# Altium schematic sheet FPGA.SchDoc
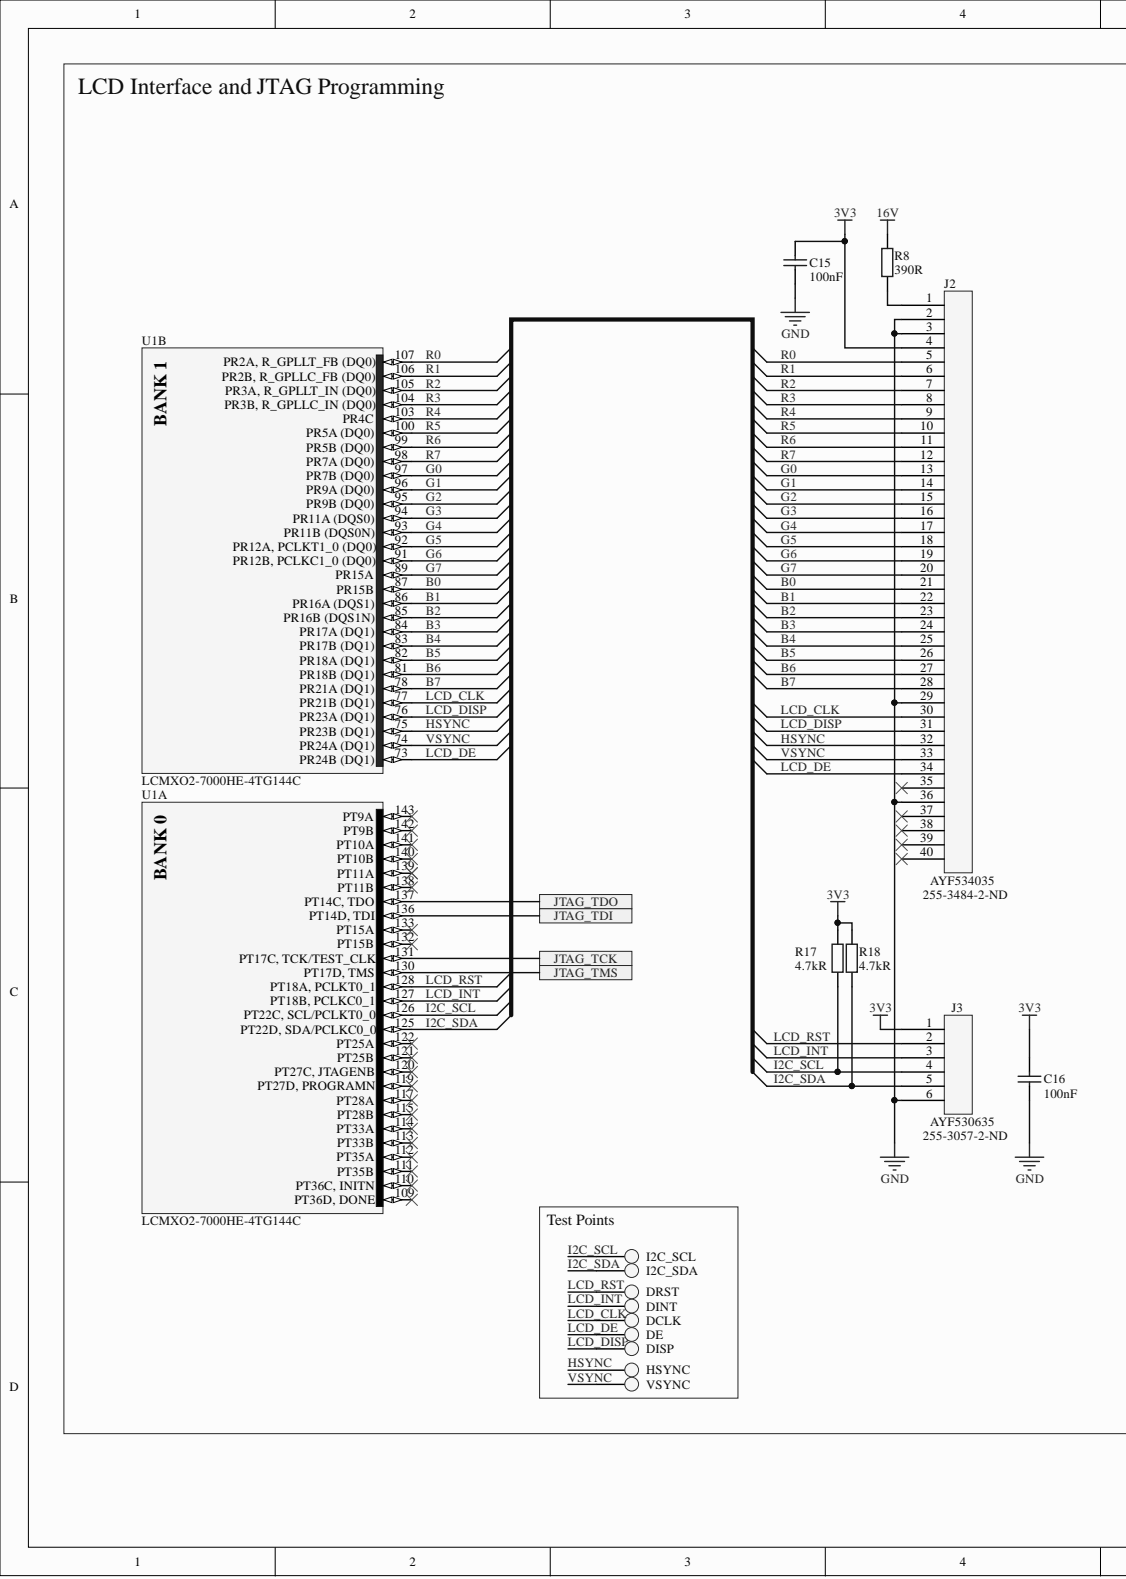
[diagram p0: FPGA.SchDoc, left]
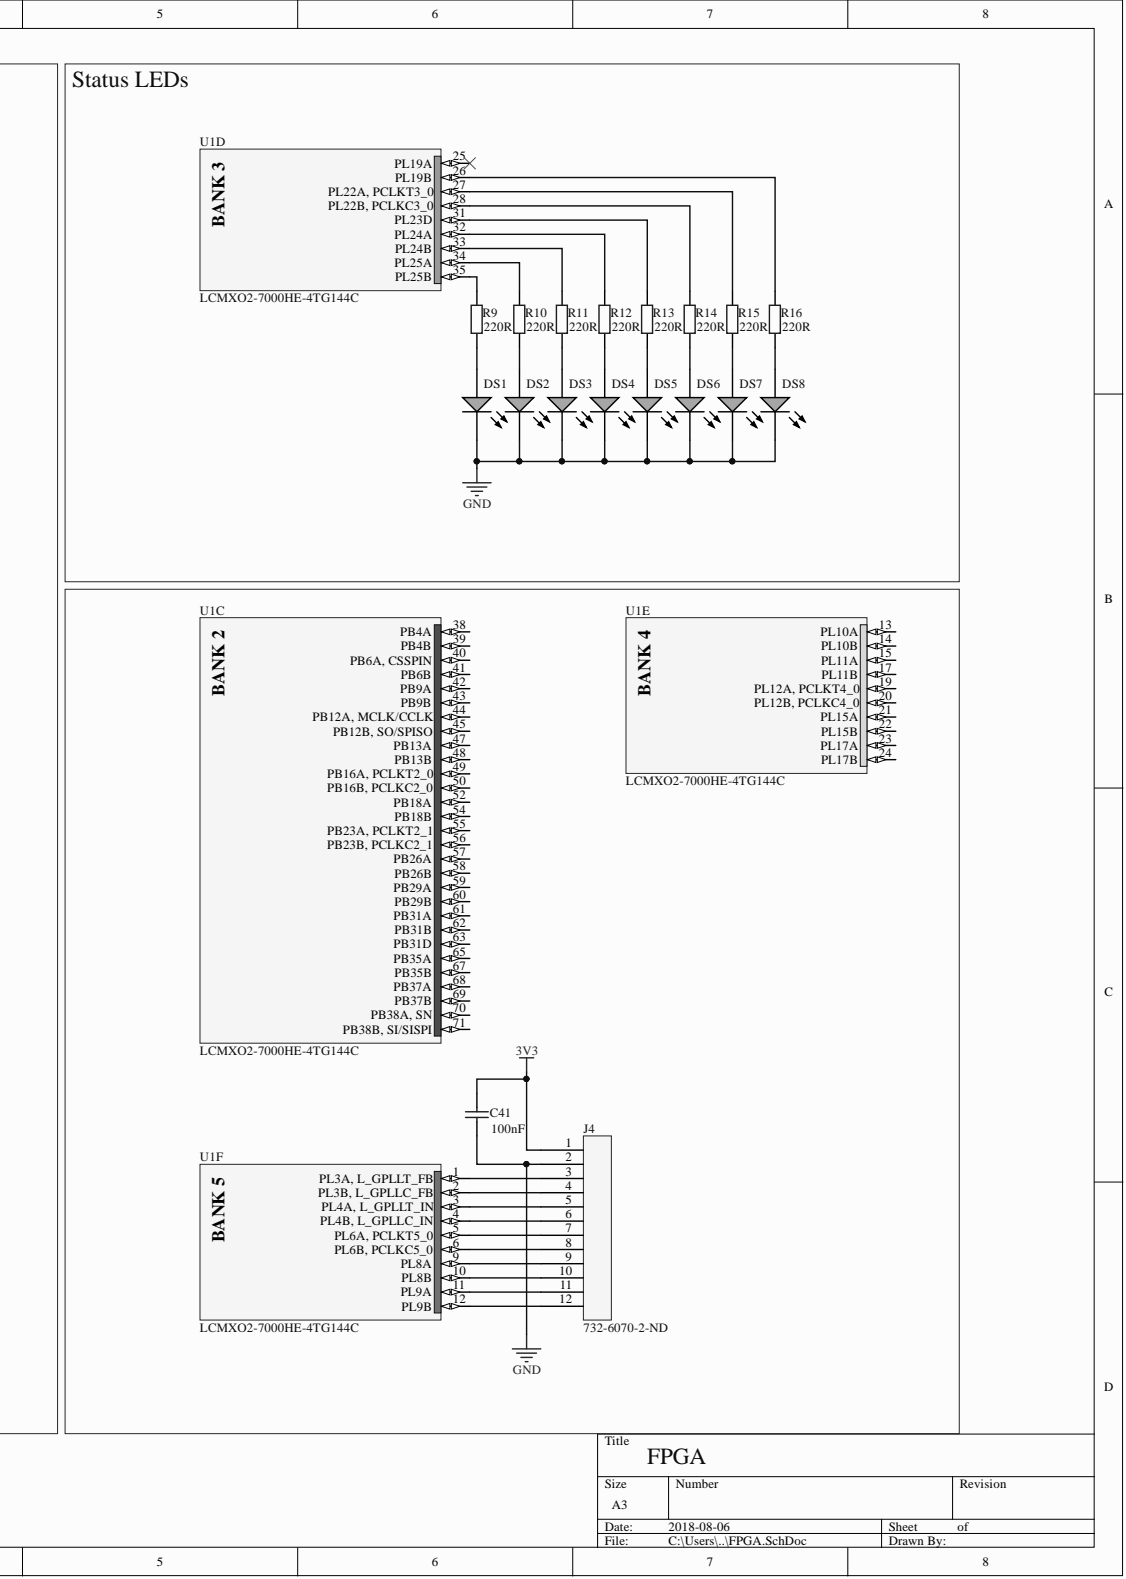
[diagram p1: FPGA.SchDoc, right]

## Parts
C15  Capacitance: 100nF  [CAP_0402_imperial]  (hidden comment: C0402C104K4RACTU)
C16  Capacitance: 100nF  [CAP_0402_imperial]  (hidden comment: C0402C104K4RACTU)
C41  Capacitance: 100nF  [CAP_0402_imperial]  (hidden comment: C0402C104K4RAC-TU)
DCLK  TP  [TP_0.75DIA]  (value not drawn)
DE  TP  [TP_0.75DIA]  (value not drawn)
DINT  TP  [TP_0.75DIA]  (value not drawn)
DISP  TP  [TP_0.75DIA]  (value not drawn)
DRST  TP  [TP_0.75DIA]  (value not drawn)
DS1  APG1608SEKC/T  [KING-LED0603-25-ORANGE_V]  (value not drawn)
DS2  APG1608SEKC/T  [KING-LED0603-25-ORANGE_V]  (value not drawn)
DS3  APG1608SEKC/T  [KING-LED0603-25-ORANGE_V]  (value not drawn)
DS4  APG1608SEKC/T  [KING-LED0603-25-ORANGE_V]  (value not drawn)
DS5  APG1608SEKC/T  [KING-LED0603-25-ORANGE_V]  (value not drawn)
DS6  APG1608SEKC/T  [KING-LED0603-25-ORANGE_V]  (value not drawn)
DS7  APG1608SEKC/T  [KING-LED0603-25-ORANGE_V]  (value not drawn)
DS8  APG1608SEKC/T  [KING-LED0603-25-ORANGE_V]  (value not drawn)
HSYNC  TP  [TP_0.75DIA]  (value not drawn)
I2C_SCL  TP  [TP_0.75DIA]  (value not drawn)
I2C_SDA  TP  [TP_0.75DIA]  (value not drawn)
J2  AYF534035  Supplier Part Number 1: 255-3484-2-ND  [AYF534035]
J3  AYF530635  Supplier Part Number 1: 255-3057-2-ND  [AYF530635]
J4  Supplier Part Number 1: 732-6070-2-ND  [610112249121]  (hidden comment: 610112249121)
R8  Resistance: 390R  [RES_0603_imperial]  (hidden comment: 390R 1% 2512(6432))
R9  Resistance: 220R  [RES_0603_imperial]  (hidden comment: 220R 1% 0603(1608))
R10  Resistance: 220R  [RES_0603_imperial]  (hidden comment: 220R 1% 0603(1608))
R11  Resistance: 220R  [RES_0603_imperial]  (hidden comment: 220R 1% 0603(1608))
R12  Resistance: 220R  [RES_0603_imperial]  (hidden comment: 220R 1% 0603(1608))
R13  Resistance: 220R  [RES_0603_imperial]  (hidden comment: 220R 1% 0603(1608))
R14  Resistance: 220R  [RES_0603_imperial]  (hidden comment: 220R 1% 0603(1608))
R15  Resistance: 220R  [RES_0603_imperial]  (hidden comment: 220R 1% 0603(1608))
R16  Resistance: 220R  [RES_0603_imperial]  (hidden comment: 220R 1% 0603(1608))
R17  Resistance: 4.7kR  [RES_0603_imperial]  (hidden comment: 4K7 1% 0603(1608))
R18  Resistance: 4.7kR  [RES_0603_imperial]  (hidden comment: 4K7 1% 0603(1608))
U1  LCMXO2-7000HE-4TG144C  [TQFP144_L]  (parts A,B,C,D,E,F)
VSYNC  TP  [TP_0.75DIA]  (value not drawn)

## Ports
JTAG_TCK, JTAG_TDI, JTAG_TDO, JTAG_TMS

## Nets
3V3: C15.1 C16.1 C41.2 J2.4 J3.1 J4.1 R17.2 R18.2
16V: R8.2
GND: C15.2 C16.2 C41.1 DS1.2 DS2.2 DS3.2 DS4.2 DS5.2 DS6.2 DS7.2 DS8.2 J2.2 J2.3 J2.29 J2.36 J3.6 J4.2
B0: J2.21 U1.87(PR15B)
B1: J2.22 U1.86(PR16A_(DQS1))
B2: J2.23 U1.85(PR16B_(DQS1N))
B3: J2.24 U1.84(PR17A_(DQ1))
B4: J2.25 U1.83(PR17B_(DQ1))
B5: J2.26 U1.82(PR18A_(DQ1))
B6: J2.27 U1.81(PR18B_(DQ1))
B7: J2.28 U1.78(PR21A_(DQ1))
G0: J2.13 U1.97(PR7B_(DQ0))
G1: J2.14 U1.96(PR9A_(DQ0))
G2: J2.15 U1.95(PR9B_(DQ0))
G3: J2.16 U1.94(PR11A_(DQS0))
G4: J2.17 U1.93(PR11B_(DQS0N))
G5: J2.18 U1.92(PR12A,_PCLKT1_0_(DQ0))
G6: J2.19 U1.91(PR12B,_PCLKC1_0_(DQ0))
G7: J2.20 U1.89(PR15A)
HSYNC: HSYNC.1 J2.32 U1.75(PR23B_(DQ1))
I2C_SCL: I2C_SCL.1 J3.4 R17.1 U1.126(PT22C,_SCL/PCLKT0_0)
I2C_SDA: I2C_SDA.1 J3.5 R18.1 U1.125(PT22D,_SDA/PCLKC0_0)
JTAG_TCK: U1.131(PT17C,_TCK/TEST_CLK) ; port JTAG_TCK
JTAG_TDI: U1.136(PT14D,_TDI) ; port JTAG_TDI
JTAG_TDO: U1.137(PT14C,_TDO) ; port JTAG_TDO
JTAG_TMS: U1.130(PT17D,_TMS) ; port JTAG_TMS
LCD_CLK: DCLK.1 J2.30 U1.77(PR21B_(DQ1))
LCD_DE: DE.1 J2.34 U1.73(PR24B_(DQ1))
LCD_DISP: DISP.1 J2.31 U1.76(PR23A_(DQ1))
LCD_INT: DINT.1 J3.3 U1.127(PT18B,_PCLKC0_1)
LCD_RST: DRST.1 J3.2 U1.128(PT18A,_PCLKT0_1)
R0: J2.5 U1.107(PR2A,_R_GPLLT_FB_(DQ0))
R1: J2.6 U1.106(PR2B,_R_GPLLC_FB_(DQ0))
R2: J2.7 U1.105(PR3A,_R_GPLLT_IN_(DQ0))
R3: J2.8 U1.104(PR3B,_R_GPLLC_IN_(DQ0))
R4: J2.9 U1.103(PR4C)
R5: J2.10 U1.100(PR5A_(DQ0))
R6: J2.11 U1.99(PR5B_(DQ0))
R7: J2.12 U1.98(PR7A_(DQ0))
VSYNC: J2.33 U1.74(PR24A_(DQ1)) VSYNC.1
NetDS1_1: DS1.1 R9.1
NetDS2_1: DS2.1 R10.1
NetDS3_1: DS3.1 R11.1
NetDS4_1: DS4.1 R12.1
NetDS5_1: DS5.1 R13.1
NetDS6_1: DS6.1 R14.1
NetDS7_1: DS7.1 R15.1
NetDS8_1: DS8.1 R16.1
NetJ2_1: J2.1 R8.1
NetJ4_3: J4.3 U1.1(PL3A,_L_GPLLT_FB)
NetJ4_4: J4.4 U1.2(PL3B,_L_GPLLC_FB)
NetJ4_5: J4.5 U1.3(PL4A,_L_GPLLT_IN)
NetJ4_6: J4.6 U1.4(PL4B,_L_GPLLC_IN)
NetJ4_7: J4.7 U1.5(PL6A,_PCLKT5_0)
NetJ4_8: J4.8 U1.6(PL6B,_PCLKC5_0)
NetJ4_9: J4.9 U1.9(PL8A)
NetJ4_10: J4.10 U1.10(PL8B)
NetJ4_11: J4.11 U1.11(PL9A)
NetJ4_12: J4.12 U1.12(PL9B)
NetR9_2: R9.2 U1.35(PL25B)
NetR10_2: R10.2 U1.34(PL25A)
NetR11_2: R11.2 U1.33(PL24B)
NetR12_2: R12.2 U1.32(PL24A)
NetR13_2: R13.2 U1.31(PL23D)
NetR14_2: R14.2 U1.28(PL22B,_PCLKC3_0)
NetR15_2: R15.2 U1.27(PL22A,_PCLKT3_0)
NetR16_2: R16.2 U1.26(PL19B)
N00028:

Not connected: J2 35 37 38 39 40; U1 13(PL10A) 14(PL10B) 15(PL11A) 17(PL11B) 19(PL12A,_PCLKT4_0) 20(PL12B,_PCLKC4_0) 21(PL15A) 22(PL15B) 23(PL17A) 24(PL17B) 25(PL19A) 38(PB4A) 39(PB4B) 40(PB6A,_CSSPIN) 41(PB6B) 42(PB9A) 43(PB9B) 44(PB12A,_MCLK/CCLK) 45(PB12B,_SO/SPISO) 47(PB13A) 48(PB13B) 49(PB16A,_PCLKT2_0) 50(PB16B,_PCLKC2_0) 52(PB18A) 54(PB18B) 55(PB23A,_PCLKT2_1) 56(PB23B,_PCLKC2_1) 57(PB26A) 58(PB26B) 59(PB29A) 60(PB29B) 61(PB31A) 62(PB31B) 63(PB31D) 65(PB35A) 67(PB35B) 68(PB37A) 69(PB37B) 70(PB38A,_SN) 71(PB38B,_SI/SISPI) 109(PT36D,_DONE) 110(PT36C,_INITN) 111(PT35B) 112(PT35A) 113(PT33B) 114(PT33A) 115(PT28B) 117(PT28A) 119(PT27D,_PROGRAMN) 120(PT27C,_JTAGENB) 121(PT25B) 122(PT25A) 132(PT15B) 133(PT15A) 138(PT11B) 139(PT11A) 140(PT10B) 141(PT10A) 142(PT9B) 143(PT9A)

## Library data of the parts
C15 C16 C41 = C0402C104K4RAC-TU: CAP CER 0.1UF 16V X7R 0402; Manufacturer: KEMET; Dielectric Material: Ceramic; Dissipation Factor: 3.5%; Tolerance: 10%; Case/Package: 0402; Case Code (Imperial): 0402; Case Code (Metric): 1005; Component Kind: Standard; ComponentLink1Description: https://datasheet.ciiva.com/28645/1851736-28645381.pdf; ComponentLink1URL: https://datasheet.ciiva.com/28645/1851736-28645381.pdf; ComponentLink2Description: https://datasheet.ciiva.com/5418/kem-c1002-x7r-smd-5418136.pdf; ComponentLink2URL: https://datasheet.ciiva.com/5418/kem-c1002-x7r-smd-5418136.pdf; ComponentLink3Description: https://datasheet.ciiva.com/14586/70095383-14586056.pdf; ComponentLink3URL: https://datasheet.ciiva.com/14586/70095383-14586056.pdf; ComponentLink4Description: https://datasheet.ciiva.com/18100/getdatasheetpartid-37082-18100986.pdf; ComponentLink4URL: https://datasheet.ciiva.com/18100/getdatasheetpartid-37082-18100986.pdf; ComponentLink5Description: https://datasheet.ciiva.com/14603/70095383-14603039.pdf; ComponentLink5URL: https://datasheet.ciiva.com/14603/70095383-14603039.pdf; Contact Plating: Tin,Matte; Depth: 0.5mm; Dielectric: X7R; Height: 0.5mm; Lead Pitch: 0.3mm; Length: 1mm; Library Name: LCD-Controller.IntLib; Manufacturer Part Number: C0402C104K4RAC-TU; Max Operating Temperature: 125°C; Min Operating Temperature: -55°C; Mount: Surface Mount,PCB; Number of Pins: 2; Package Quantity: 1; Packaging: Tape and Reel; Radiation Hardening: No; REACH SVHC: No SVHC; Stock 1: 0; Supplier 1: Digi-Key; Supplier Part Number 1: 399-3521-6-ND; Termination: SMD/SMT; Thickness: 0.022inch; Voltage: 16V; Voltage Rating: 16V; Voltage Rating (DC): 16V; Width: 0.02inch
DS1-DS8 = CMP-0239-00002-1: LED, SMT, 0603(1608), 0.25mm Tthickness, Super Bright Orange; Forward Current: 30 mA; Packing: Reel; Power Dissipation: 75 mW; Reverse Voltage: 5 V; Component Kind: Standard; ComponentLink1Description: Manufacturer URL; ComponentLink1URL: http://www.kingbrightusa.com/; ComponentLink2Description: Datasheet; ComponentLink2URL: http://www.kingbrightusa.com/images/catalog/SPEC/APG1608SEKC-T.pdf; DatasheetVersion: Rev. 4A, 12/2011; Library Name: Altium Content Vault; Mounting Technology: Surface Mount; PackageDescription: 2-Pin SMT LED, 1.6 x 0.8 mm Body, 0.25mm Thickness; PackageReference: LED0603-25-ORANGE; PackageVersion: Rev. 4A, 12/2011; PartNumber: APG1608SEKC/T; Storage Temperature: -40 to 85 degC
J2 = AYF534035: CONN FFC FPC 40POS 0.50MM R/A; Manufacturer: Panasonic; Actuator Material: Polymer,Liquid Crystal Polymer; Contact Location: Dual; Current Rating: 500mA; Number of Positions: 40; Pitch: 0.02inch; Voltage Rating: 50V; Component Kind: Standard; ComponentLink1Description: http://components.arrow.com/part/dataview/0323_03238277; ComponentLink1URL: http://components.arrow.com/part/dataview/0323_03238277; ComponentLink2Description: http://www.mouser.com/ds/2/315/Panasonic_Y5B_Y5BW%20Series-1076217.pdf; ComponentLink2URL: http://www.mouser.com/ds/2/315/Panasonic_Y5B_Y5BW%20Series-1076217.pdf; ComponentLink3Description: http://www3.panasonic.biz/ac/e_download/control/connector/fpc-ffc/catalog/con_eng_y5bw.pdf; ComponentLink3URL: http://www3.panasonic.biz/ac/e_download/control/connector/fpc-ffc/catalog/con_eng_y5bw.pdf; Contact Material: Copper Alloy; Contact Plating: Gold; Flammability Rating: UL94 V-0; Housing Material: Polymer; Library Name: Connectors.SchLib; Manufacturer Part Number: AYF534035; Max Operating Temperature: 85°C; Min Operating Temperature: -55°C; Mount: Surface Mount; Package Quantity: 1000; Packaging: Tape and Reel; Pricing 1: 5000=1.1438 (USD); Stock 1: 10000; Supplier 1: Digi-Key; Termination: Solder
J3 = AYF530635: CONN FFC FPC 6POS 0.50MM R/A; Manufacturer: Panasonic; Actuator Material: Polymer,Liquid Crystal Polymer; Contact Location: Dual; Contact Resistance: 80mR; Current Rating: 500mA; Max Voltage Rating (DC): 5.05kV; Number of Contacts: 6; Pitch: 0.5mm; Voltage Rating: 50V; Component Kind: Standard; ComponentLink1Description: https://datasheet.ciiva.com/15249/eng-y5bw-15249222.pdf; ComponentLink1URL: https://datasheet.ciiva.com/15249/eng-y5bw-15249222.pdf; Contact Material: Copper Alloy; Contact Plating: Gold; Flammability Rating: UL94 V-0; Housing Material: Polymer; Library Name: Connectors.IntLib; Manufacturer Part Number: AYF530635; Max Operating Temperature: 85°C; Min Operating Temperature: -55°C; Mount: Surface Mount; Number of Positions: 6; Number of Rows: 1; Orientation: Right Angle; Package Quantity: 1; Packaging: Tape and Reel; Pricing 1: 5000=0.798, 10000=0.78 (USD); Radiation Hardening: No; Stock 1: 0; Supplier 1: Digi-Key; Termination: Solder
J4 = 610112249121: Pin header 12P, 610112249121, Würth Elektronik; Manufacturer: Wurth Electronics; Connector Type: Male Pin; Current Rating: 3A; Gender: Male; Number of Contacts: 12; Pitch: 0.1inch; Colour: Black; Component Kind: Standard; ComponentLink1Description: http://katalog.we-online.de/em/datasheet/6101xx249121.pdf; ComponentLink1URL: http://katalog.we-online.de/em/datasheet/6101xx249121.pdf; ComponentLink2Description: https://www.distrelec.bizhttp://katalog.we-online.de/em/datasheet/6101xx249121.pdf?mime=application%2Fpdf; ComponentLink2URL: https://www.distrelec.bizhttp://katalog.we-online.de/em/datasheet/6101xx249121.pdf?mime=application%2Fpdf; ComponentLink3Description: http://www.farnell.com/cad/1889791.pdf; ComponentLink3URL: http://www.farnell.com/cad/1889791.pdf; Contact Material: Copper Alloy; Contact Mating Length: 0.236inch; Contact Plating: Gold; Library Name: LCD-Controller.IntLib; Manufacturer Part Number: 610112249121; Mount: Surface Mount; Number of Positions: 12; Number of Rows: 2; Packaging: Cut Tape; Pricing 1: 350=1.884, 700=1.64901 (USD); REACH SVHC: Unknown; Row Spacing: 0.1inch; Stock 1: 0; Supplier 1: Digi-Key; Termination: Solder
R8 = ERA3AEB391V: PANASONIC ELECTRONIC COMPONENTS         ERA3AEB391V             SMD Chip Resistor, Thin Film, 390 ohm, 75 V, 0603 [1608 Metric], 100 mW,  0.1%, ERA Series; Max Power Dissipation: 100mW; Temperature Coefficient: 25ppm/°C; Voltage Rating: 75V; Case/Package: 0603; Case Code (Imperial): 0603; Case Code (Metric): 1608; Component Kind: Standard; ComponentLink1Description: https://datasheet.ciiva.com/6001/485442-6001685.pdf; ComponentLink1URL: https://datasheet.ciiva.com/6001/485442-6001685.pdf; ComponentLink2Description: https://datasheet.ciiva.com/6001/485442-6001686.pdf; ComponentLink2URL: https://datasheet.ciiva.com/6001/485442-6001686.pdf; ComponentLink3Description: https://datasheet.ciiva.com/9182/aoa0000ce26-9182342.pdf; ComponentLink3URL: https://datasheet.ciiva.com/9182/aoa0000ce26-9182342.pdf; ComponentLink4Description: https://datasheet.ciiva.com/48425/era-eng-tds-48425462.pdf; ComponentLink4URL: https://datasheet.ciiva.com/48425/era-eng-tds-48425462.pdf; ComponentLink5Description: https://datasheet.ciiva.com/7909/485442-7909096.pdf; ComponentLink5URL: https://datasheet.ciiva.com/7909/485442-7909096.pdf; ComponentLink6Description: https://datasheet.ciiva.com/7909/485442-7909097.pdf; ComponentLink6URL: https://datasheet.ciiva.com/7909/485442-7909097.pdf; ComponentLink7Description: https://datasheet.ciiva.com/7909/485442-7909098.pdf; ComponentLink7URL: https://datasheet.ciiva.com/7909/485442-7909098.pdf; ComponentLink8Description: https://datasheet.ciiva.com/22737/39837-22737548.pdf; ComponentLink8URL: https://datasheet.ciiva.com/22737/39837-22737548.pdf; ComponentLink9Description: https://datasheet.ciiva.com/11808/0900766b80fe20f7-11808046.pdf; ComponentLink9URL: https://datasheet.ciiva.com/11808/0900766b80fe20f7-11808046.pdf; ComponentLink10Description: https://datasheet.ciiva.com/17205/aoa0000ce26-17205855.pdf; ComponentLink10URL: https://datasheet.ciiva.com/17205/aoa0000ce26-17205855.pdf; ComponentLink11Description: https://datasheet.ciiva.com/29183/450555-da-01-en-widerstand-0603-era-29183689.pdf; ComponentLink11URL: https://datasheet.ciiva.com/29183/450555-da-01-en-widerstand-0603-era-29183689.pdf; Composition: Thin Film; Contact Plating: Tin; Depth: 0.8mm; Height: 0.45mm; Lead Free: Lead Free; Length: 1.6mm; Library Name: LCD-Controller.IntLib; Manufacturer: Panasonic; Manufacturer Part Number: ERA3AEB391V; Max Operating Temperature: 155°C; Military Standard: Not; Min Operating Temperature: -55°C; Mount: Surface Mount; Number of Pins: 2; Number of Terminations: 2; Package Quantity: 1; Packaging: Tape and Reel; Power Rating: 100mW; Pricing 1: 1=0.41, 10=0.346, 100=0.1351, 1000=0.05655, 2500=0.05184 (USD); Radiation Hardening: No; REACH SVHC: No SVHC; Stock 1: 37643; Supplier 1: Digi-Key; Supplier Part Number 1: P390DBDKR-ND; Termination: Solder; Tolerance: 0.1%; Voltage Rating (DC): 75V; Width: 0.8mm
R9-R16 = ERA-3AEB221V: Res Thin Film 0603 220 Ohm 0.1% 1/10W ±25ppm/C Molded SMD Punched Carrier T/R; Manufacturer: Panasonic; Max Power Dissipation: 100mW; Temperature Coefficient: 25ppm/°C; Voltage Rating: 75V; Case/Package: 0603; Case Code (Imperial): 0603; Case Code (Metric): 1608; Component Kind: Standard; ComponentLink1Description: https://datasheet.ciiva.com/30089/54aoa0000ce26-30089206.pdf; ComponentLink1URL: https://datasheet.ciiva.com/30089/54aoa0000ce26-30089206.pdf; ComponentLink2Description: https://datasheet.ciiva.com/11808/0900766b80fe20f7-11808055.pdf; ComponentLink2URL: https://datasheet.ciiva.com/11808/0900766b80fe20f7-11808055.pdf; ComponentLink3Description: https://datasheet.ciiva.com/48530/era-eng-tds-48530567.pdf; ComponentLink3URL: https://datasheet.ciiva.com/48530/era-eng-tds-48530567.pdf; ComponentLink4Description: https://datasheet.ciiva.com/9117/aoa0000ce26-9117624.pdf; ComponentLink4URL: https://datasheet.ciiva.com/9117/aoa0000ce26-9117624.pdf; ComponentLink5Description: https://datasheet.ciiva.com/22739/485442-22739289.pdf; ComponentLink5URL: https://datasheet.ciiva.com/22739/485442-22739289.pdf; ComponentLink6Description: https://datasheet.ciiva.com/7886/485442-7886586.pdf; ComponentLink6URL: https://datasheet.ciiva.com/7886/485442-7886586.pdf; ComponentLink7Description: https://datasheet.ciiva.com/9184/aoa0000ce26-9184316.pdf; ComponentLink7URL: https://datasheet.ciiva.com/9184/aoa0000ce26-9184316.pdf; ComponentLink8Description: https://datasheet.ciiva.com/43455/485442-43455212.pdf; ComponentLink8URL: https://datasheet.ciiva.com/43455/485442-43455212.pdf; ComponentLink9Description: https://datasheet.ciiva.com/6000/485442-6000910.pdf; ComponentLink9URL: https://datasheet.ciiva.com/6000/485442-6000910.pdf; ComponentLink10Description: https://datasheet.ciiva.com/17206/aoa0000ce26-17206814.pdf; ComponentLink10URL: https://datasheet.ciiva.com/17206/aoa0000ce26-17206814.pdf; ComponentLink11Description: https://datasheet.ciiva.com/48425/era-eng-tds-48425439.pdf; ComponentLink11URL: https://datasheet.ciiva.com/48425/era-eng-tds-48425439.pdf; ComponentLink12Description: https://datasheet.ciiva.com/29183/450451-da-01-en-widerstand-0603-era-29183676.pdf; ComponentLink12URL: https://datasheet.ciiva.com/29183/450451-da-01-en-widerstand-0603-era-29183676.pdf; Composition: Metal Film,Thin Film; Contact Plating: Tin; Depth: 0.8mm; Features: Automotive AEC-Q200; Height: 0.45mm; Lead Free: Lead Free; Length: 1.6mm; Library Name: LCD-Controller.IntLib; Manufacturer Part Number: ERA-3AEB221V; Max Operating Temperature: 155°C; Military Standard: Not; Min Operating Temperature: -55°C; Mount: Surface Mount; Number of Pins: 2; Number of Terminations: 2; Package Quantity: 1; Packaging: Tape and Reel; Power Rating: 100mW; Pricing 1: 1=0.41, 10=0.346, 100=0.1351, 1000=0.05655, 2500=0.05184 (USD); Radiation Hardening: No; REACH SVHC: No SVHC; Stock 1: 240; Supplier 1: Digi-Key; Supplier Part Number 1: P220DBDKR-ND; Termination: SMD/SMT; Tolerance: 0.1%; Voltage Rating (DC): 75V; Width: 0.8mm
R17 R18 = ERA-3AEB472V: Res Thin Film 0603 4.7K Ohm 0.1% 0.1W(1/10W) ±25ppm/C Molded SMD Automotive Punched T/R; Manufacturer: Panasonic; Max Power Dissipation: 100mW; Temperature Coefficient: 25ppm/°C; Voltage Rating: 75V; Case/Package: 0603; Case Code (Imperial): 0603; Case Code (Metric): 1608; Component Kind: Standard; ComponentLink1Description: https://datasheet.ciiva.com/22743/485442-22743061.pdf; ComponentLink1URL: https://datasheet.ciiva.com/22743/485442-22743061.pdf; ComponentLink2Description: https://datasheet.ciiva.com/46422/485442-46422693.pdf; ComponentLink2URL: https://datasheet.ciiva.com/46422/485442-46422693.pdf; ComponentLink3Description: https://datasheet.ciiva.com/11808/0900766b80fe20f7-11808039.pdf; ComponentLink3URL: https://datasheet.ciiva.com/11808/0900766b80fe20f7-11808039.pdf; ComponentLink4Description: https://datasheet.ciiva.com/9116/aoa0000ce26-9116681.pdf; ComponentLink4URL: https://datasheet.ciiva.com/9116/aoa0000ce26-9116681.pdf; ComponentLink5Description: https://datasheet.ciiva.com/22781/485442-22781497.pdf; ComponentLink5URL: https://datasheet.ciiva.com/22781/485442-22781497.pdf; ComponentLink6Description: https://datasheet.ciiva.com/9117/aoa0000ce26-9117707.pdf; ComponentLink6URL: https://datasheet.ciiva.com/9117/aoa0000ce26-9117707.pdf; ComponentLink7Description: https://datasheet.ciiva.com/43455/485442-43455227.pdf; ComponentLink7URL: https://datasheet.ciiva.com/43455/485442-43455227.pdf; ComponentLink8Description: https://datasheet.ciiva.com/16772/70068849-16772572.pdf; ComponentLink8URL: https://datasheet.ciiva.com/16772/70068849-16772572.pdf; ComponentLink9Description: https://datasheet.ciiva.com/48425/era-eng-tds-48425470.pdf; ComponentLink9URL: https://datasheet.ciiva.com/48425/era-eng-tds-48425470.pdf; ComponentLink10Description: https://datasheet.ciiva.com/30078/54aoa0000ce26-30078815.pdf; ComponentLink10URL: https://datasheet.ciiva.com/30078/54aoa0000ce26-30078815.pdf; ComponentLink11Description: https://datasheet.ciiva.com/29183/451124-da-01-en-widerstand-0603-era-29183789.pdf; ComponentLink11URL: https://datasheet.ciiva.com/29183/451124-da-01-en-widerstand-0603-era-29183789.pdf; Composition: Metal Film,Thin Film; Contact Plating: Tin; Depth: 0.8mm; Height: 0.45mm; Lead Free: Lead Free; Length: 1.6mm; Library Name: LCD-Controller.IntLib; Manufacturer Part Number: ERA-3AEB472V; Max Operating Temperature: 155°C; Military Standard: Not; Min Operating Temperature: -55°C; Mount: Surface Mount; Number of Pins: 2; Number of Terminations: 2; Package Quantity: 5000; Packaging: Tape and Reel; Power Rating: 100mW; Pricing 1: 1=0.41, 10=0.346, 100=0.1351, 1000=0.05655, 2500=0.05184 (USD); Radiation Hardening: No; REACH SVHC: No SVHC; Stock 1: 123784; Supplier 1: Digi-Key; Supplier Part Number 1: P4.7KDBDKR-ND; Termination: SMD/SMT; Tolerance: 0.1%; Voltage Rating (DC): 75V; Width: 0.8mm; Working Voltage: 75V
U1 = CMP-0111-00327-1: MachXO2 High Performance CPLD with 6864 LUTs, 1.2V, 144-pin TQFP, Speed Grade-4, Commercial Grade, Halogen Free (RoHS); Code_JEDEC: MS-026-BFB; Component Kind: Standard; DatasheetVersion: ver01.0, Nov-2010; Library Name: Altium Content Vault; PackageDescription: 144-Pin Thin Quad Flat Pack (TQFP144); PackageReference: TQFP144; PackageVersion: ver02.6, Nov-2010; PartId: 1
DCLK DE DINT DISP DRST HSYNC I2C_SCL I2C_SDA VSYNC = TP: Component Kind: Standard; Library Name: LCD-Controller.SchLib
